# Altium schematic sheet Microcontroller.SchDoc
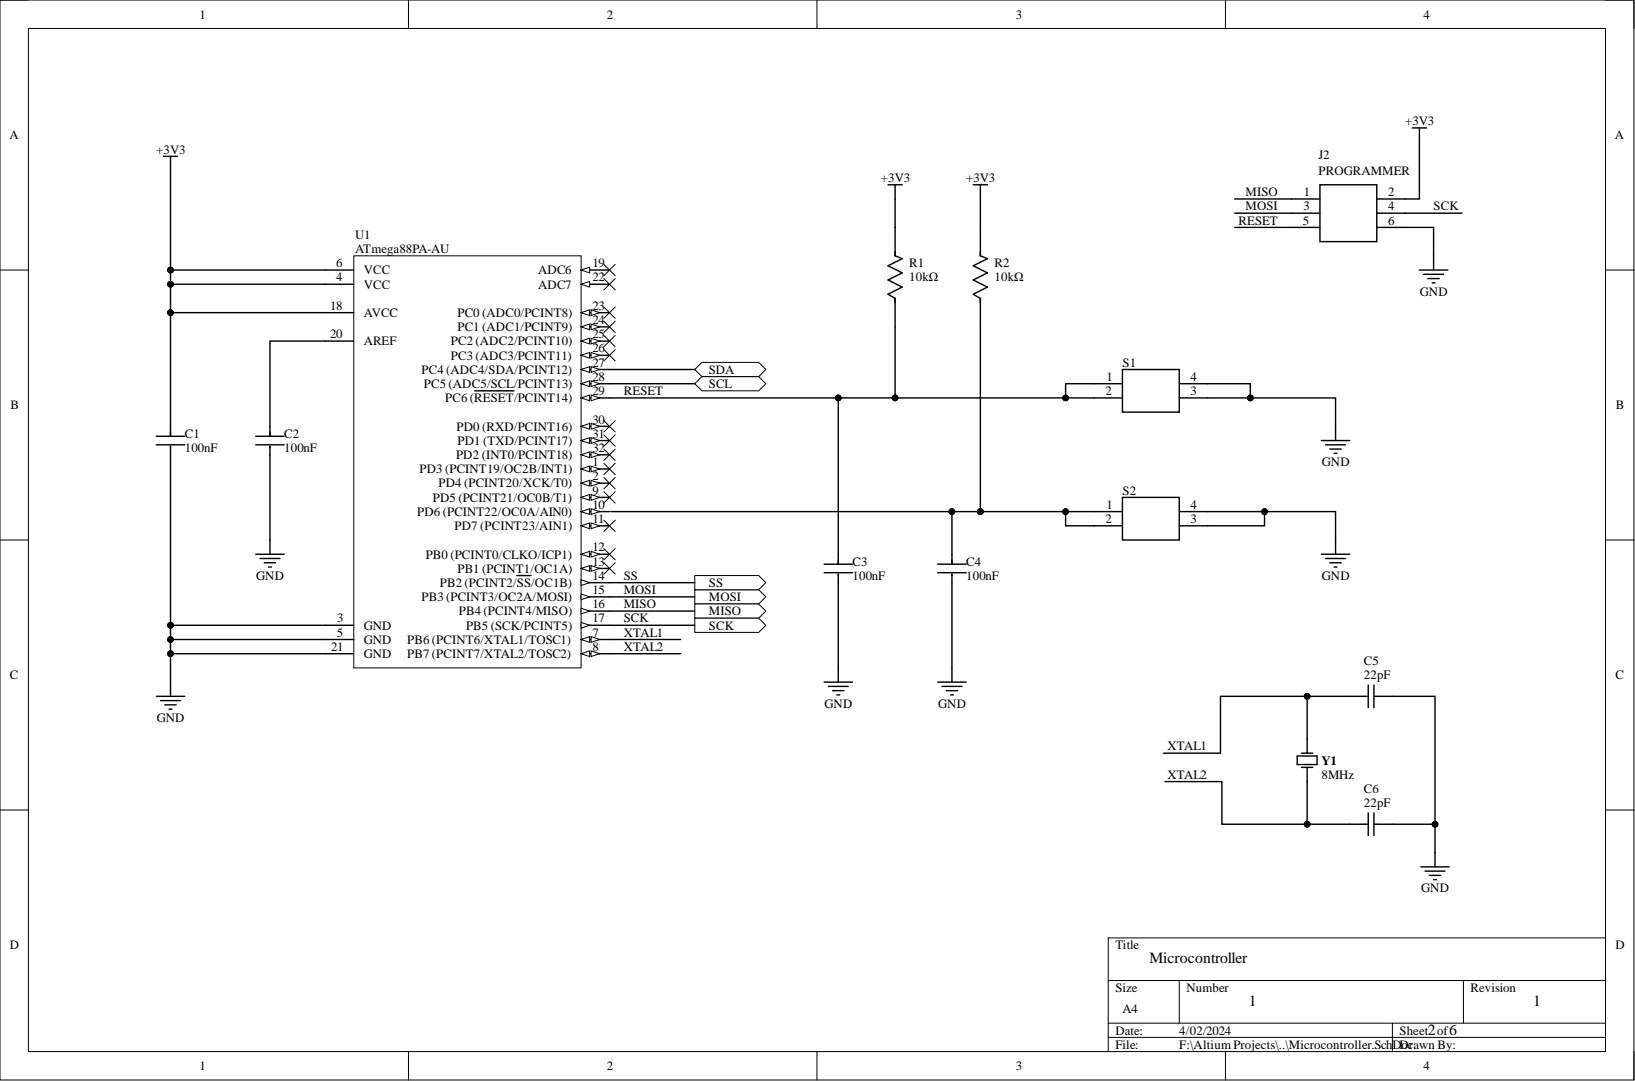
[diagram p0: Microcontroller.SchDoc]

## Parts
C1  value 100nF  [FP-C1206C-EB-MFG]  (hidden comment: C1206C104K5RAC7210)
C2  value 100nF  [FP-C1206C-EB-MFG]  (hidden comment: C1206C104K5RAC7210)
C3  value 100nF  [FP-C1206C-EB-MFG]  (hidden comment: C1206C104K5RAC7210)
C4  value 100nF  [FP-C1206C-EB-MFG]  (hidden comment: C1206C104K5RAC7210)
C5  Capacitance: 22pF  [WCAP-CSGP_1206_L3.2W1.6H0.8]  (hidden comment: 885012008011)
C6  Capacitance: 22pF  [WCAP-CSGP_1206_L3.2W1.6H0.8]  (hidden comment: 885012008011)
J2  Name: PROGRAMMER  [HDRV6W90P254_2X3_762X500X560P]  (hidden comment: 90131-0763)
R1  Resistance: 10kΩ  [FP-RNCP1206-IPC_B]  (hidden comment: RNCP1206FTD10K0)
R2  Resistance: 10kΩ  [FP-RNCP1206-IPC_B]  (hidden comment: RNCP1206FTD10K0)
S1  PTS647SM50SMTR2LFS  [PTS647SM50SMTR2LFS]  (value not drawn)
S2  PTS647SM50SMTR2LFS  [PTS647SM50SMTR2LFS]  (value not drawn)
U1  ATmega88PA-AU  [32A_L]
Y1  value 8MHz  [FOX-FOXSLF080-20]  (hidden comment: FOXSLF/080-20)

## Ports
MISO, MOSI, SCK, SCL, SDA, SS

## Nets
+3V3: C1.1 J2.2 R1.2 R2.2 U1.4(VCC) U1.6(VCC) U1.18(AVCC)
GND: C1.2 C2.2 C3.2 C4.2 C5.2 C6.2 J2.6 S1.3 S1.4 S2.3 S2.4 U1.3(GND) U1.5(GND) U1.21(GND)
MISO: J2.1 U1.16(PB4_(PCINT4/MISO)) ; port MISO
MOSI: J2.3 U1.15(PB3_(PCINT3/OC2A/MOSI)) ; port MOSI
RESET: C3.1 J2.5 R1.1 S1.1 S1.2 U1.29(PC6_(RESET/PCINT14))
SCK: J2.4 U1.17(PB5_(SCK/PCINT5)) ; port SCK
SCL: U1.28(PC5_(ADC5/SCL/PCINT13)) ; port SCL
SDA: U1.27(PC4_(ADC4/SDA/PCINT12)) ; port SDA
SS: U1.14(PB2_(PCINT2/SS/OC1B)) ; port SS
XTAL1: C5.1 U1.7 Y1.2
XTAL2: C6.1 U1.8 Y1.1
NetC2_1: C2.1 U1.20(AREF)
NetC4_1: C4.1 R2.1 S2.1 S2.2
NetU1_1: U1.1(PD3_(PCINT19/OC2B/INT1))
NetU1_2: U1.2(PD4_(PCINT20/XCK/T0))
NetU1_9: U1.9(PD5_(PCINT21/OC0B/T1))
NetU1_10: U1.10(PD6_(PCINT22/OC0A/AIN0))
NetU1_11: U1.11(PD7_(PCINT23/AIN1))
NetU1_12: U1.12(PB0_(PCINT0/CLKO/ICP1))
NetU1_13: U1.13(PB1_(PCINT1/OC1A))
NetU1_19: U1.19(ADC6)
NetU1_22: U1.22(ADC7)
NetU1_23: U1.23(PC0_(ADC0/PCINT8))
NetU1_24: U1.24(PC1_(ADC1/PCINT9))
NetU1_25: U1.25
NetU1_26: U1.26(PC3_(ADC3/PCINT11))
NetU1_30: U1.30(PD0_(RXD/PCINT16))
NetU1_31: U1.31(PD1_(TXD/PCINT17))
NetU1_32: U1.32(PD2_(INT0/PCINT18))

## Library data of the parts
C1-C4 = CMP-03020-003377-1: CAP CER 0.1UF 50V X7R 1206; Manufacturer: KEMET; Automotive Grade: Grade 0; Capacitance: 0.10 μF; Material: Ceramic; Temperature Coefficient: 15%; Tolerance: 0.1; Automotive: Yes; Category: Cap; Component Kind: Standard; ComponentLink2Description: Datasheet; ComponentLink2URL: https://content.kemet.com/datasheets/KEM_C1007_X8R_ULTRA_150C_SMD.pdf; Device Class L1: Passive Components; Device Class L2: Capacitors; Device Class L3: Ceramic Capacitors; Digikey Description: CAP CER 0.1UF 50V X7R 1206; Height: 0.88mm; Ipc Land Pattern Name: CAPC320160X78; Lead Free: Yes; Library Name: Altium Content Vault; Manufacturer Part Number: C1206C104K5RAC7210; Mouser Description: Multilayer Ceramic Capacitors MLCC - SMD/SMT 50volts 0.1uF X7R 10%; Octopart: https://octopart.com/c1206c104k5rac7210-kemet-3919856; Package: C1206C-EB; RoHS: TRUE; Temperature: -55°C to +150°C; Temperature Characteristic: X7R; Temperature Range High: +150°C; Temperature Range Low: -55°C; Voltage Rating: 50 V
C5 C6 = CMP-03913-65419657-1: 885012008011 Ceramic Capacitor 22pF, 16VDC, 1206, ±5 %; Insulation Resistance: 10GΩ; Tolerance: 5%; Voltage Rating: 16V; Case Code (Imperial): 1206; Case Code (Metric): 3216; Case/Package: 1206; Component Kind: Standard; Height: 800µm; Length: 3.2mm; Library Name: Altium Content Vault; Max Operating Temperature: 125°C; Min Operating Temperature: -55°C; Mount: Surface Mount; NexarPartId: 65419657; RoHS: Compliant; Thickness: 889µm; Voltage Rating (DC): 16V; Width: 1.6mm
J2 = 90131-0763: Connector; Manufacturer_Name: Molex; Component Kind: Standard; Datasheet Link: https://www.molex.com/pdm_docs/sd/901310763_sd.pdf; Height: 5.6mm; Manufacturer_Part_Number: 90131-0763; Mouser Part Number: 538-90131-0763; Mouser Price/Stock: https://www.mouser.co.uk/ProductDetail/Molex/90131-0763?qs=KObwnG1V4zuKPiIchrbqlw%3D%3D
R1 R2 = CMP-26527-005574-1: 10kΩ ±1% 0.5W 1206 High Power Anti-Sulfur Thin Film Chip Resistor; Max Power Dissipation: 500mW; Temperature Coefficient: 100ppm/°C; Case Code (Imperial): 1206; Case Code (Metric): 3216; Case/Package: 1206; Component Kind: Standard; Features: Moisture Resistant; Height: 0.024inch; Length: 0.122inch; Library Name: Altium Content Vault; Max Operating Temperature: 155°C; Min Operating Temperature: -55°C; Mount: Surface Mount; Number of Terminations: 2; Packaging: Tape and Reel; Resistor Type: Thin Film; RoHS Compliant: Yes; Series: RNCP; Tolerance: 1%; Width: 0.059inch
S1 S2 = PTS647SM50SMTR2LFS: Switch; Manufacturer_Name: C & K COMPONENTS; Arrow Part Number: PTS647SM50SMTR2LFS; Arrow Price/Stock: https://www.arrow.com/en/products/pts-647-sm50-smtr2-lfs/ck?region=europe; Component Kind: Standard; Datasheet Link: https://www.ckswitches.com/media/2567/pts647.pdf; Height: 5.25mm; Manufacturer_Part_Number: PTS647SM50SMTR2LFS; Mouser Part Number: 611-PTS647SM50SMTR2L; Mouser Price/Stock: https://www.mouser.co.uk/ProductDetail/CK/PTS647SM50SMTR2LFS?qs=PqoDHHvF64%252BjK4ZB7f42kw%3D%3D
U1 = CMP-0095-00213-2: 8-bit AVR Microcontroller, 8KB Flash, 512 Bytes EEPROM, 1KB SRAM, 32-pin TQFP, Industrial Grade (-40°C to 85°C); Code_JEDEC: MS-026-ABA; Component Kind: Standard; ComponentLink1Description: Manufacturer URL; ComponentLink1URL: http://www.atmel.com/; ComponentLink2Description: ATmega48A/48PA/88A/88PA/168A/168PA/328/328P Datasheet; ComponentLink2URL: http://www.atmel.com/dyn/resources/prod_documents/doc8271.pdf; ComponentLink3Description: ATmega48A/48PA/88A/88PA/168A/168PA/328/328P Summary; ComponentLink3URL: http://www.atmel.com/dyn/resources/prod_documents/8271S.pdf; DatasheetVersion: revD, May-2011; Library Name: Altium Content Vault; PackageDescription: 32-Lead, 7x7x1.0 mm Body, 0.8mm Lead Pitch, Thin Profile Plastic Quad Flat Package (TQFP); PackageReference: 32A; PackageVersion: revC, Oct-2010; RevisionNote: DatasheetVersion & PackageVersion updated. URLs added.
Y1 = CMP-1749-00002-1: Resistance Weld Thru-Hole Crystal, 8 MHz, -20 to 70 degC, 2-Pin THD, RoHS, Bulk; Case\Package: TO-263-3; Dropout Voltage: 2 V; Max Input Voltage: 35 V; Max Ouput Current: 1 A; Min Input Voltage: 7 V; Nominal Output Voltage: 5 V; Outputs: 1; Component Kind: Standard; ComponentLink1Description: Manufacturer URL; ComponentLink1URL: http://www.foxonline.com/; ComponentLink2Description: Datasheet; ComponentLink2URL: http://download.siliconexpert.com/pdfs/2015/4/5/6/5/7/200/fox_/manual/35607121449963728hc49slf.pdf; DatasheetVersion: Mar-15; Height: 30.02 mm; Length: 10.24 mm; Library Name: Altium Content Vault; Max Operating Temperature: 125 degC; Min Operating Temperature: 0 degC; Mount: Surface Mount; Output Current: 1 A; Output Voltage: 5 V; Package Quantity: 50; PackageDescription: 2-Pin Through Hole Device, Body 11.35 x 4.65 mm; PackageReference: FOXSLF080-20; Packaging: Rail/Tube; Pins: 3; Radiation Hardening: No; REACH SVHC: No SVHC; RoHSCompliant: true; Width: 9.35 mm
